# Altium schematic sheet chipshouter-pico-mcu.SchDoc
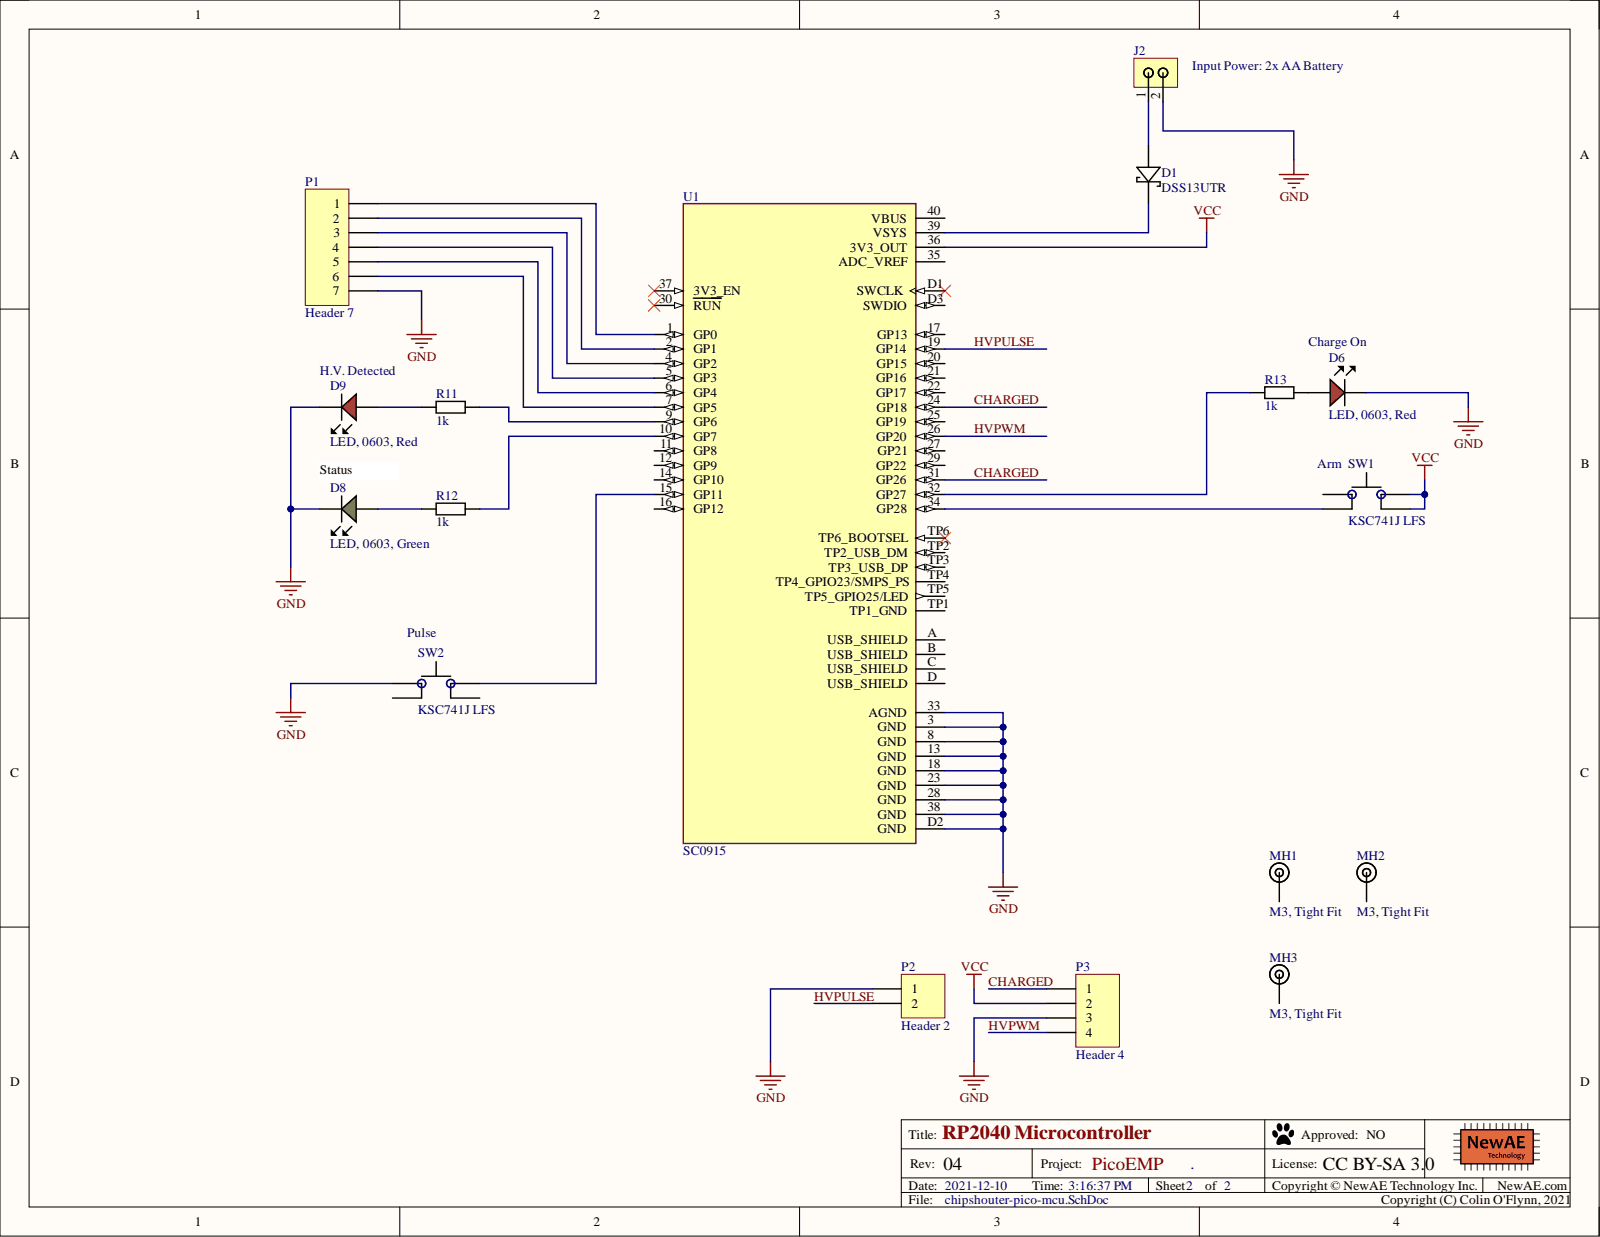
[diagram p0: chipshouter-pico-mcu.SchDoc]

## Parts
D1  DSS13UTR  [DSS13UTR]
D6  LED, 0603, Red  [LED-0603-RED]
D8  LED, 0603, Green  [LED-0603-GREEN]
D9  LED, 0603, Red  [LED-0603-RED]
J2  1x2_Pin_Header  [22-23-2021]  (value not drawn)
MH1  M3, Tight Fit  [MOUNT_M3_TIGHT]  (mechanical)
MH2  M3, Tight Fit  [MOUNT_M3_TIGHT]  (mechanical)
MH3  M3, Tight Fit  [MOUNT_M3_TIGHT]  (mechanical)
P1  Header 7  [HDR1X7]
P2  Header 2  [HDR1X2]
P3  Header 4  [HDR1X4]
R11  1k  [0603]
R12  1k  [0603]
R13  1k  [0603]
SW1  KSC741J LFS  [CK_KSC7J]
SW2  KSC741J LFS  [CK_KSC7J]
U1  SC0915  [MODULE_SC0915]

## Nets
GND: D6.K D8.K D9.K J2.2 P1.7 P2.1 P3.3 SW2.1 U1.3(GND) U1.8(GND) U1.13(GND) U1.18(GND) U1.23(GND) U1.28(GND) U1.33(AGND) U1.38(GND) U1.D2(GND)
VCC: P3.2 SW1.2 SW1.3 U1.36(3V3_OUT)
CHARGED: P3.1 U1.24(GP18) U1.31(GP26)
HVPULSE: P2.2 U1.19(GP14)
HVPWM: P3.4 U1.26(GP20)
NetD1_A: D1.A J2.1
NetD1_K: D1.K U1.39(VSYS)
NetD6_A: D6.A R13.1
NetD8_A: D8.A R12.1
NetD9_A: D9.A R11.1
NetP1_1: P1.1 U1.1(GP0)
NetP1_2: P1.2 U1.2(GP1)
NetP1_3: P1.3 U1.4(GP2)
NetP1_4: P1.4 U1.5(GP3)
NetP1_5: P1.5 U1.6(GP4)
NetP1_6: P1.6 U1.7(GP5)
NetR11_2: R11.2 U1.9(GP6)
NetR12_2: R12.2 U1.10(GP7)
NetR13_2: R13.2 U1.32(GP27)
NetSW1_4: SW1.4 U1.34(GP28)
NetSW2_2: SW2.2 U1.15(GP11)

Not connected: MH1 1; MH2 1; MH3 1; SW1 1; SW2 3 4; U1 11(GP8) 12(GP9) 14(GP10) 16(GP12) 17(GP13) 20(GP15) 21(GP16) 22(GP17) 25(GP19) 27(GP21) 29(GP22) 30(RUN) 35(ADC_VREF) 37(3V3_EN) 40(VBUS) A(USB_SHIELD) B(USB_SHIELD) C(USB_SHIELD) D(USB_SHIELD) D1(SWCLK) D3(SWDIO) TP1(TP1_GND) TP2(TP2_USB_DM) TP3(TP3_USB_DP) TP4(TP4_GPIO23/SMPS_PS) TP5(TP5_GPIO25/LED) TP6(TP6_BOOTSEL)

## Library data of the parts
D1 = DSS13UTR: SCHOTTKY 30V SOD-123FL; Manufacture 1: SMC Diode Solutions; Component Group: Diode; Database Table Name: main; PP Material Stack: 0; Component Kind: Standard; Component Type: Standard; Library Name: NewAE_AltiumLib.DBLib; Manufacture Part Number 1: DSS13UTR; Mounting Technology: SMT; Part Number: DSS13UTR; Pin Count: 2; PP Rotation: 0; RoHS: Yes; Supplier 1: Digi-Key; Supplier Part Number 1: 1655-1926-1-ND
D6 D9 = LEDCHIP_0603_RED: Chip LED, Red; Manufacture 1: Kingbright; Manufacture Part Number 1: APT1608SRCPRV; Component Group: Optical; Database Table Name: main; PP Material Stack: 15; PP Rotation: -90; Component Kind: Standard; Component Type: Standard; Library Name: NewAE_AltiumLib.DBLib; Mounting Technology: SMT; Part Number: LEDCHIP_0603_RED; Pin Count: 2; Supplier 1: Digi-Key; Supplier Part Number 1: 754-1122-1-ND
D8 = LEDCHIP_0603_GREEN: Chip LED, Green; Manufacture 1: Kingbright; Manufacture Part Number 1: APT1608CGCK; Component Group: Optical; Database Table Name: main; PP Material Stack: 16; PP Rotation: -90; Component Kind: Standard; Component Type: Standard; Library Name: NewAE_AltiumLib.DBLib; Mounting Technology: SMT; Part Number: LEDCHIP_0603_GREEN; Pin Count: 2; Supplier 1: Digi-Key; Supplier Part Number 1: 754-1116-1-ND
J2 = Molex_2_Pin: CONN HEADER 2POS .100 VERT TIN; Manufacture 1: Molex; Manufacture Part Number 1: 22-23-2021 22-23-2021; Component Group: Connector; Database Table Name: main; PP Material Stack: 0; Component Kind: Standard; Component Type: Standard; Library Name: NewAE_AltiumLib.DBLib; Mounting Technology: Through-hole; Part Number: Molex_2_Pin; Pin Count: 2; PP Rotation: 0; RoHS: Yes; Supplier 1: Digi-Key; Supplier Part Number 1: WM4200-ND
MH1-MH3 = MOUNT_M3_TIGHT: Machine screw M3 Mounting Hole; Component Group: Mechanical; Database Table Name: main; PP Material Stack: 0; Component Type: Mechanical; Library Name: NewAE_AltiumLib.DBLib; Part Number: MOUNT_M3_TIGHT; Pin Count: 1; PP Rotation: 0
P1 = Header 7: Header, 7-Pin; Component Kind: Standard; LatestRevisionDate: 17-Jul-2002; LatestRevisionNote: Re-released for DXP Platform.; Library Name: Miscellaneous Connectors.IntLib; Signal Integrity: Connector; Publisher: Altium Limited
P2 = Header 2: Header, 2-Pin; Component Kind: Standard; LatestRevisionDate: 17-Jul-2002; LatestRevisionNote: Re-released for DXP Platform.; Library Name: Miscellaneous Connectors.IntLib; Signal Integrity: Connector; Publisher: Altium Limited
P3 = Header 4: Header, 4-Pin; Component Kind: Standard; LatestRevisionDate: 17-Jul-2002; LatestRevisionNote: Re-released for DXP Platform.; Library Name: Miscellaneous Connectors.IntLib; Signal Integrity: Connector; Publisher: Altium Limited
R11-R13 = RCHIP-1K-0603: 1k res, 0603, 1%, 1/10W; Manufacture 1: Yageo; Manufacture Part Number 1: RC0603FR-071KL; Case-Metric: 1608; Component Group: Resistor; Database Table Name: main; Rated Power: 0.1W; Rated Voltage: 75VDC; Case-EIA: 0603; Component Kind: Standard; Component Type: Standard; Library Name: NewAE_AltiumLib.DBLib; Mounting Technology: SMT; Part Number: RCHIP-1K-0603; Pin Count: 2; Supplier 1: Digi-Key; Supplier Part Number 1: 311-1.00KHRCT-ND; Temperature Range: -55C to 155C; Tolerance: 1%; Value: 1k
SW1 SW2 = TACTSW-KSC741J: SWITCH TACTILE SPST-NO 0.01A 32V; Manufacture 1: C&K; Database Table Name: main; PP Material Stack: 0; Component Group: Switch; Component Kind: Standard; Component Type: Standard; Library Name: NewAE_AltiumLib.DBLib; Manufacture Part Number 1: KSC741J LFS; Mounting Technology: SMT; Part Number: TACTSW-KSC741J; Pin Count: 4; PP Rotation: 0; RoHS: Yes; Supplier 1: Digi-Key; Supplier Part Number 1: CKN10309CT-ND
U1 = SC0915: Raspberry Pi Pico; Component Group: PCB Feature; Database Table Name: main; PP Material Stack: 0; Availability: In Stock; Check_prices: https://www.snapeda.com/parts/SC0915/Raspberry%20Pi/view-part/6331605/?ref=eda; Component Kind: Standard; Component Type: Standard; Library Name: NewAE_AltiumLib.DBLib; MF: Raspberry Pi; MP: SC0915; Package: None; Part Number: SC0915; PP Rotation: 0; PP Rotation1: 0; Price: None; Purchase-URL: https://pricing.snapeda.com/search/part/SC0915/?ref=eda; SnapEDA_Link: https://www.snapeda.com/parts/SC0915/Raspberry%20Pi/view-part/6331605/?ref=snap
